# Altium schematic sheet 4 USB.SchDoc
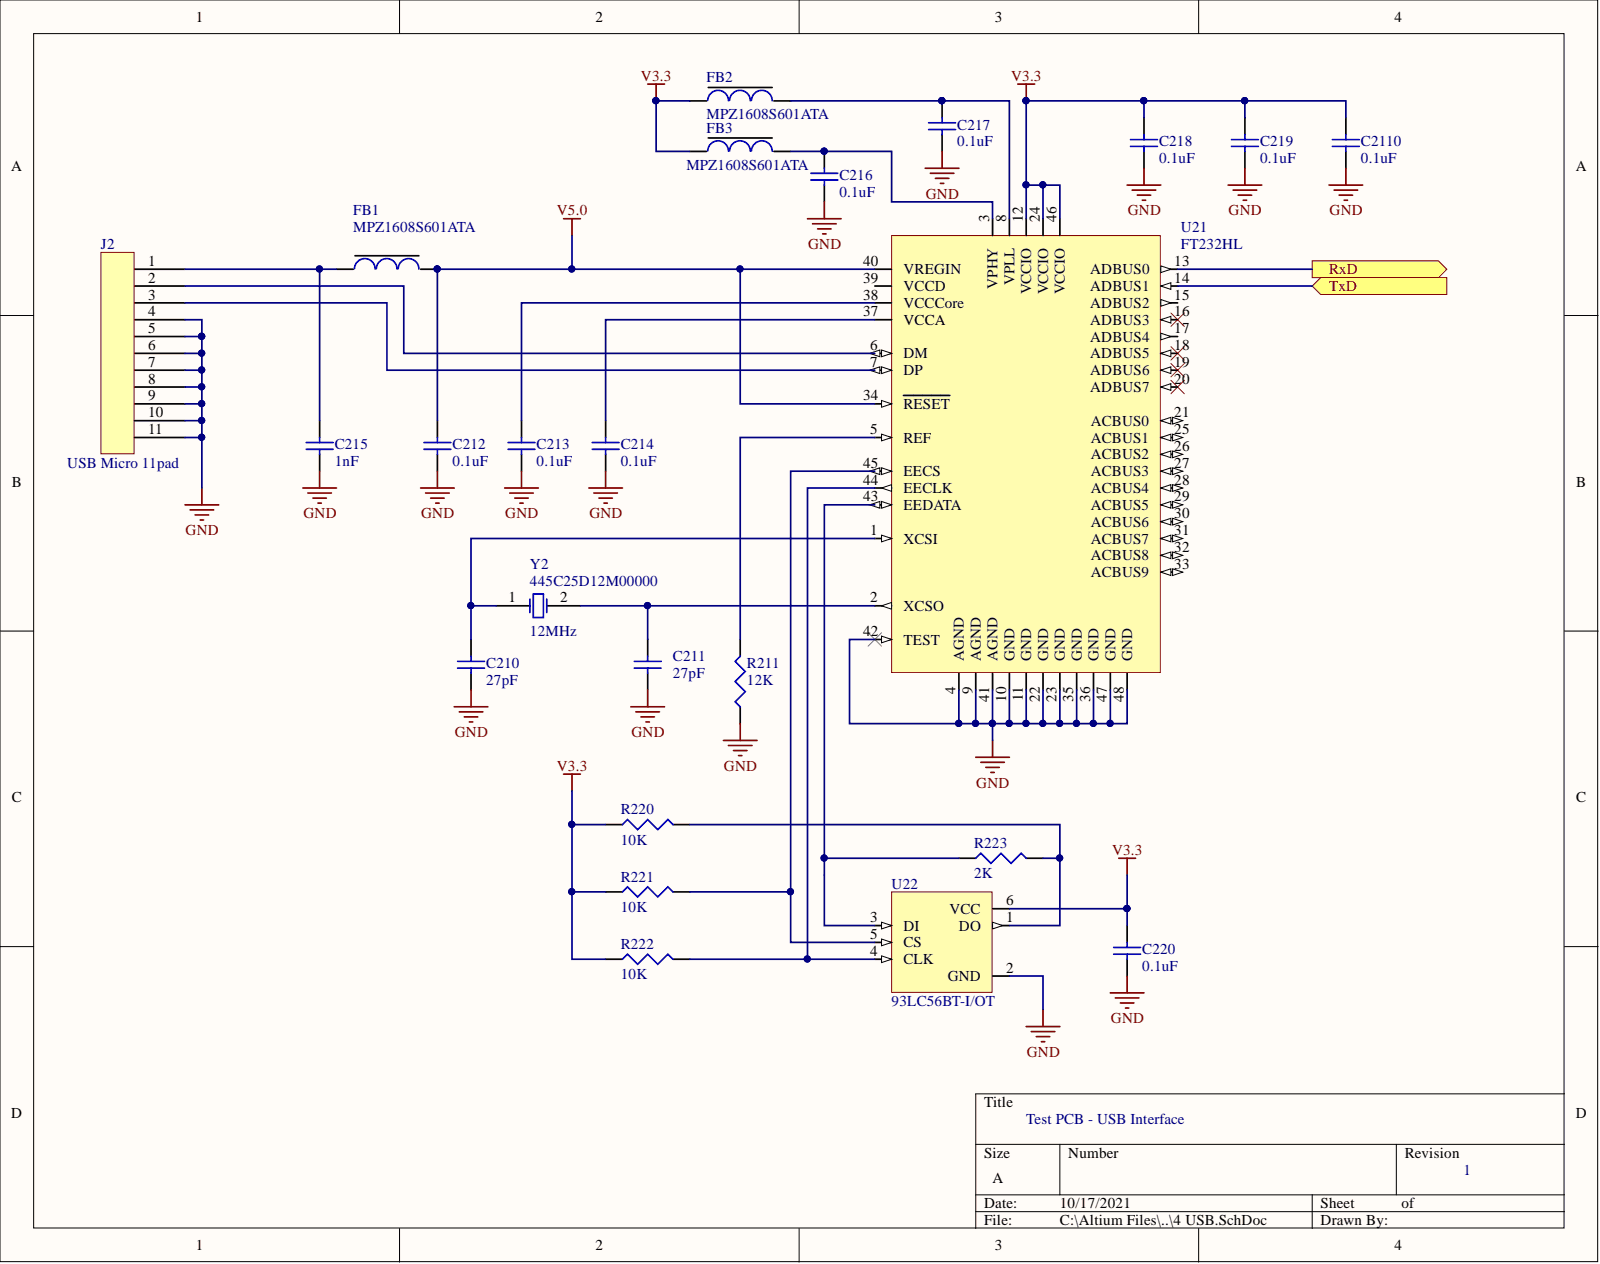
[diagram p0: 4 USB.SchDoc]

## Parts
C210  27pF  [C0603]
C211  27pF  [C0603]
C212  0.1uF  [C0402]
C213  0.1uF  [C0402]
C214  0.1uF  [C0402]
C215  1nF  [C0603]
C216  0.1uF  [C0402]
C217  0.1uF  [C0402]
C218  0.1uF  [C0402]
C219  0.1uF  [C0402]
C220  0.1uF  [C0402]
C2110  0.1uF  [C0402]
FB1  MPZ1608S601ATA  [MPZ1608]
FB2  MPZ1608S601ATA  [MPZ1608]
FB3  MPZ1608S601ATA  [MPZ1608]
J2  USB Micro 11pad  [FCI 10118193]
R211  12K  [R0603]
R220  10K  [R0603]
R221  10K  [R0603]
R222  10K  [R0603]
R223  2K  [R0603]
U21  FT232HL  [QFP50P900X900X160-48N]
U22  93LC56BT-I/OT  [SOT23-6]
Y2  445C25D12M00000  [445 Series xtal]

## Ports
RxD, TxD

## Nets
GND: C210.1 C211.1 C212.1 C213.1 C214.1 C215.1 C216.1 C217.1 C218.1 C219.1 C220.1 C2110.1 J2.4 J2.5 J2.6 J2.7 J2.8 J2.9 J2.10 J2.11 R211.1 U21.4(AGND) U21.9(AGND) U21.10(GND) U21.11(GND) U21.22(GND) U21.23(GND) U21.35(GND) U21.36(GND) U21.41(AGND) U21.42(TEST) U21.47(GND) U21.48(GND) U22.2(GND)
V3.3: C218.2 C219.2 C220.2 C2110.2 FB2.1 FB3.1 R220.1 R221.1 R222.1 U21.12(VCCIO) U21.24(VCCIO) U21.46(VCCIO) U22.6(VCC)
V5.0: C212.2 FB1.2 U21.34(RESET) U21.40(VREGIN)
RxD: U21.13(ADBUS0) ; port RxD
TxD: U21.14(ADBUS1) ; port TxD
NetC210_2: C210.2 U21.1(XCSI) Y2.1
NetC211_2: C211.2 U21.2(XCSO) Y2.2
NetC213_2: C213.2 U21.38(VCCCore)
NetC214_2: C214.2 U21.37(VCCA)
NetC215_2: C215.2 FB1.1 J2.1
NetC216_2: C216.2 FB3.2 U21.3(VPHY)
NetC217_2: C217.2 FB2.2 U21.8(VPLL)
NetJ2_2: J2.2 U21.6(DM)
NetJ2_3: J2.3 U21.7(DP)
NetR211_2: R211.2 U21.5(REF)
NetR220_2: R220.2 R223.2 U22.1(DO)
NetR221_2: R221.2 U21.45(EECS) U22.5(CS)
NetR222_2: R222.2 U21.44(EECLK) U22.4(CLK)
NetR223_1: R223.1 U21.43(EEDATA) U22.3(DI)

Not connected: U21 15(ADBUS2) 16(ADBUS3) 17(ADBUS4) 18(ADBUS5) 19(ADBUS6) 20(ADBUS7) 21(ACBUS0) 25(ACBUS1) 26(ACBUS2) 27(ACBUS3) 28(ACBUS4) 29(ACBUS5) 30(ACBUS6) 31(ACBUS7) 32(ACBUS8) 33(ACBUS9) 39(VCCD)

## Library data of the parts
C210-C220 C2110 = Cap SM: Capacitor, SM; Component Kind: Standard; LatestRevisionDate: 29-May-2009; LatestRevisionNote: IPC-7351 Footprint Added.; PackageDescription: Chip Capacitor; PackageReference: C1206; Published: 8-Jun-2000; Signal Integrity: Cap Semi; Simulation: CAP; Publisher: Altium Limited
  C210 C211: Value: 27pF
  C212-C214 C216-C220 C2110: Value: 0.1uF
  C215: Value: 1nF; Library Name: Graylark.SchLib
  C210-C214 C216-C220 C2110: Library Name: Graylark.IntLib
FB1-FB3 = Ferrite Bead: Component Kind: Standard; Library Name: Graylark.SchLib
R211 R220-R223 = Res SM: Resistor; Component Kind: Standard; LatestRevisionDate: 29-May-2009; LatestRevisionNote: IPC-7351 Footprint Added.; PackageDescription: Chip Resistor; PackageReference: J1-0603; Published: 8-Jun-2000; Signal Integrity: Res; Simulation: RESISTOR; Library Name: Graylark.IntLib; Publisher: Altium Limited
  R211: Value: 12K
  R220-R222: Value: 10K
  R223: Value: 2K
U21 = FT232HL: USB interface; Component Kind: Standard; Library Name: Graylark.IntLib
Y2 = XTAL: Crystal Oscillator; Component Kind: Standard; LatestRevisionDate: 17-Jul-2002; LatestRevisionNote: Re-released for DXP Platform.; PackageDescription: Crystal, Thru-Hole; 2 Leads; Body 3.1 x 8.2 mm (Dia.xH); PackageReference: R38; Published: 8-Jun-2000; Simulation: XTAL; Library Name: Graylark.IntLib; Publisher: Altium Limited
J2 = USB Micro 11pad: Component Kind: Standard; Library Name: Graylark.IntLib
U22 = 93LC56BT-I/OT: Component Kind: Standard; Library Name: Graylark.IntLib
